# Altium schematic sheet STM32WL_ADC.SchDoc(STM32WL_ADC)
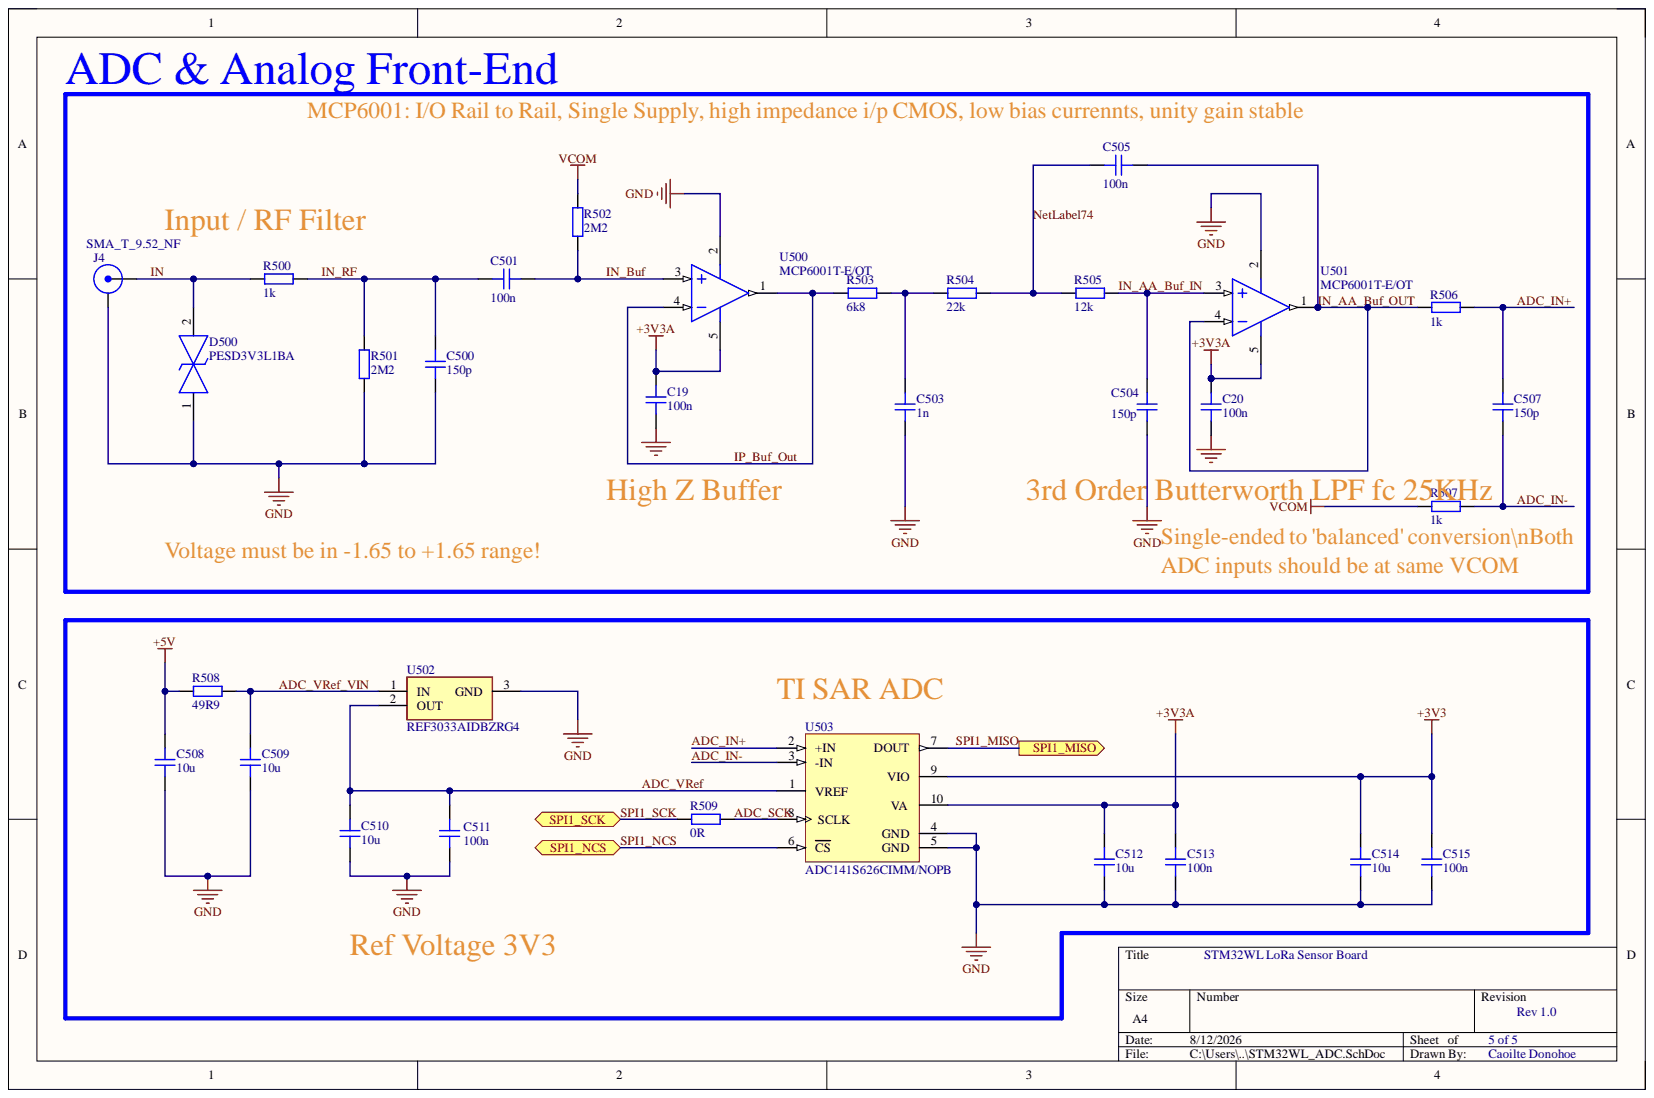
[diagram p0: STM32WL_ADC.SchDoc]

## Parts
C19  100n  [CAP_0402]
C20  100n  [CAP_0402]
C500  150p  [CAP_0402]
C501  100n  [CAP_0402]
C503  1n  [CAP_0402]
C504  150p  [CAP_0402]
C505  100n  [CAP_0402]
C507  150p  [CAP_0402]
C508  10u  [CAP_0603]
C509  10u  [CAP_0603]
C510  10u  [CAP_0603]
C511  100n  [CAP_0402]
C512  10u  [CAP_0603]
C513  100n  [CAP_0402]
C514  10u  [CAP_0603]
C515  100n  [CAP_0402]
D500  PESD3V3L1BA  [SOD2512X110N]
J4  SMA_T_9.52_NF  [CO_SAMTEC_SMA-J-P-ST-EMI]
R500  1k  [RES_0402]
R501  2M2  [RES_0402]
R502  2M2  [RES_0402]
R503  6k8  [RES_0402]
R504  22k  [RES_0402]
R505  12k  [RES_0402]
R506  1k  [RES_0402]
R507  1k  [RES_0402]
R508  49R9  [RES_0402]
R509  0R  [RES_0402]
U500  MCP6001T-E/OT  [SOT-23-OT5_L]
U501  MCP6001T-E/OT  [SOT-23-OT5_L]
U502  REF3033AIDBZRG4  [SOT95P237X112-3N]
U503  ADC141S626CIMM/NOPB  [MUB10A_M]

## Ports
SPI1_MISO, SPI1_NCS, SPI1_SCK

## Nets
+3V3: C514.2 C515.2 U503.9(VIO)
+3V3A: C19.1 C20.1 C512.2 C513.2 U500.5 U501.5 U503.10(VA)
+5V: C508.2 R508.1
GND: C19.2 C20.2 C500.1 C503.1 C504.1 C508.1 C509.1 C510.1 C511.1 C512.1 C513.1 C514.1 C515.1 D500.1 J4.2 R501.1 U500.2 U501.2 U502.3 U503.4(GND) U503.5(GND)
VCOM: R502.2 R507.2
ADC_IN+: C507.1 R506.1 U503.2(+IN)
ADC_IN-: C507.2 R507.1 U503.3(-IN)
ADC_SCK: R509.2 U503.8(SCLK)
ADC_VRef: C510.2 C511.2 U502.2 U503.1(VREF)
ADC_VRef_VIN: C509.2 R508.2 U502.1
IN: D500.2 J4.1 R500.1
IN_AA_Buf_IN: C504.2 R505.1 U501.3
IN_AA_Buf_OUT: C505.1 R506.2 U501.1 U501.4
IN_Buf: C501.1 R502.1 U500.3
IN_RF: C500.2 C501.2 R500.2 R501.2
IP_Buf_Out: R503.2 U500.1 U500.4
NetLabel74: C505.2 R504.1 R505.2
SPI1_MISO: U503.7(DOUT) ; port SPI1_MISO
SPI1_NCS: U503.6(CS) ; port SPI1_NCS
SPI1_SCK: R509.1 ; port SPI1_SCK
NetC503_2: C503.2 R503.1 R504.2

## Library data of the parts
C19 C20 C500 C501 C503-C505 C507 C511 C513 C515 = CAP_0402_16V: Capacitor SMD 0402 16V; Component Kind: Standard; Library Name: Schematic-Lib-PhilsLab.SchLib
C508-C510 C512 C514 = CAP_0603_16V: Capacitor SMD 0603 16V; Component Kind: Standard; Library Name: Schematic-Lib-PhilsLab.SchLib
D500 = PESD3V3L1BA: TVS Diode (Bi-directional); Manufacturer_Name: Nexperia; Component Kind: Standard; Datasheet Link: https://datasheet.datasheetarchive.com/originals/distributors/Datasheets-26/DSA-502681.pdf; Height: 1.1mm; Manufacturer_Part_Number: PESD3V3L1BA; Mouser Part Number: N/A; Mouser Price/Stock: https://www.mouser.co.uk/ProductDetail/Nexperia/PESD3V3L1BA?qs=vHuUswq2%252BswBNSPO0jzUyg%3D%3D
J4 = SMA_T_9.52_NF: SMA End Launch Jack 1.0 mm Board, 50 ohm; Manufacturer: COAX CONNECTORS LTD; Manufacturer_Part_Number: 30-462-D3-1.1mm; Component Kind: Standard; Library Name: MB1789_HP.SCHLIB
R500-R509 = RES_0402: Resistor SMD 0402; Component Kind: Standard; Library Name: Schematic-Lib-PhilsLab.SchLib
U500 U501 = CMP-0187-00458-3: None; Amplifier Type: GENERAL PURPOSE; Bandwidth: 1MHz; Common Mode Rejection Ratio: 60dB; Input Bias Current: 1pA; Input Offset Voltage (Vos): 4.5mV; Max Supply Voltage: 6V; Min Supply Voltage: 1.8V; Nominal Supply Current: 100uA; Number of Amplifiers: 1; Operating Supply Voltage: 5.5V; Output Current: 23mA; Power Supply Rejection Ratio (PSRR): 86dB; Slew Rate: 0.6V/µs; Voltage Gain: 112dB; Case/Package: SOT-23; Component Kind: Standard; Contact Plating: Tin; Gain Bandwidth Product: 1MHz; Height: 1.3mm; Input Offset Voltage-Max: 4.5mV; Lead Free: Lead Free; Length: 3.1mm; Library Name: Altium Content Vault; Max Operating Temperature: 125°C; Min Operating Temperature: -40°C; Mount: Surface Mount; Number of Channels: 1; Number of Circuits: 1; Number of Elements: 1; Number of Pins: 5; Operating Supply Current: 100uA; Output Current per Channel: 23mA; Package Quantity: 3000; Packaging: Tape and Reel; Radiation Hardening: No; REACH SVHC: No SVHC; RoHS Compliant: Yes; Simulation: MCP6001; Slew Rate-Nom: 0.6V/µs; Supply Current: 100uA; Technology: CMOS; Unity Gain Bandwidth Product: 1MHz; Voltage: 6V; Width: 1.8mm
U502 = REF3033AIDBZRG4: Integrated Circuit; Manufacturer_Name: Texas Instruments; Arrow Part Number: REF3033AIDBZRG4; Arrow Price/Stock: https://www.arrow.com/en/products/ref3033aidbzrg4/texas-instruments; Component Kind: Standard; Datasheet Link: https://www.ti.com/lit/ds/symlink/ref3030.pdf?ts=1639296719724; Height: 1.12mm; Manufacturer_Part_Number: REF3033AIDBZRG4; Mouser Part Number: 595-REF3033AIDBZRG4; Mouser Price/Stock: https://www.mouser.co.uk/ProductDetail/Texas-Instruments/REF3033AIDBZRG4?qs=sSOk4GDDv7x3KhdEagQjiQ%3D%3D
U503 = CMP-0054-00477-2: 14-Bit, 50 kSPS to 250 kSPS, Differential Input, Micro Power A/D Converter, 10-pin Mini SOIC, Pb-Free; Manufacturer: National Semiconductor; CompType: ADC IC; PackageTopMarking: ZXTT*X94C; PowerWise: Y; Code_JEDEC: MO-187-BA; Component Kind: Standard; ComponentLink1Description: ADC141S626 Datasheet; ComponentLink1URL: http://www.national.com/ds/AD/ADC141S626.pdf; ComponentLink2Description: ADC141S626 Web Page; ComponentLink2URL: http://www.national.com/pf/AD/ADC141S626.html; ComponentLink3Description: MUB10A Package Page; ComponentLink3URL: http://www.national.com/packaging/folders/mub10a.html; DatasheetVersion: Jan-2009; Library Name: Altium Content Vault; PackageDescription: Mini-SO, Molded, .118 x .118 x .034in Body, 10 Lead, .0197in Pitch; PackageReference: MUB10A; PackageVersion: revB, Jul-2003; PinCount: 10; RevisionNote: Component URLs added; RoHS: Y
